# Altium schematic sheet Sch-QWA17_GPIO.SchDoc(Sch-QWA17_GPIO)
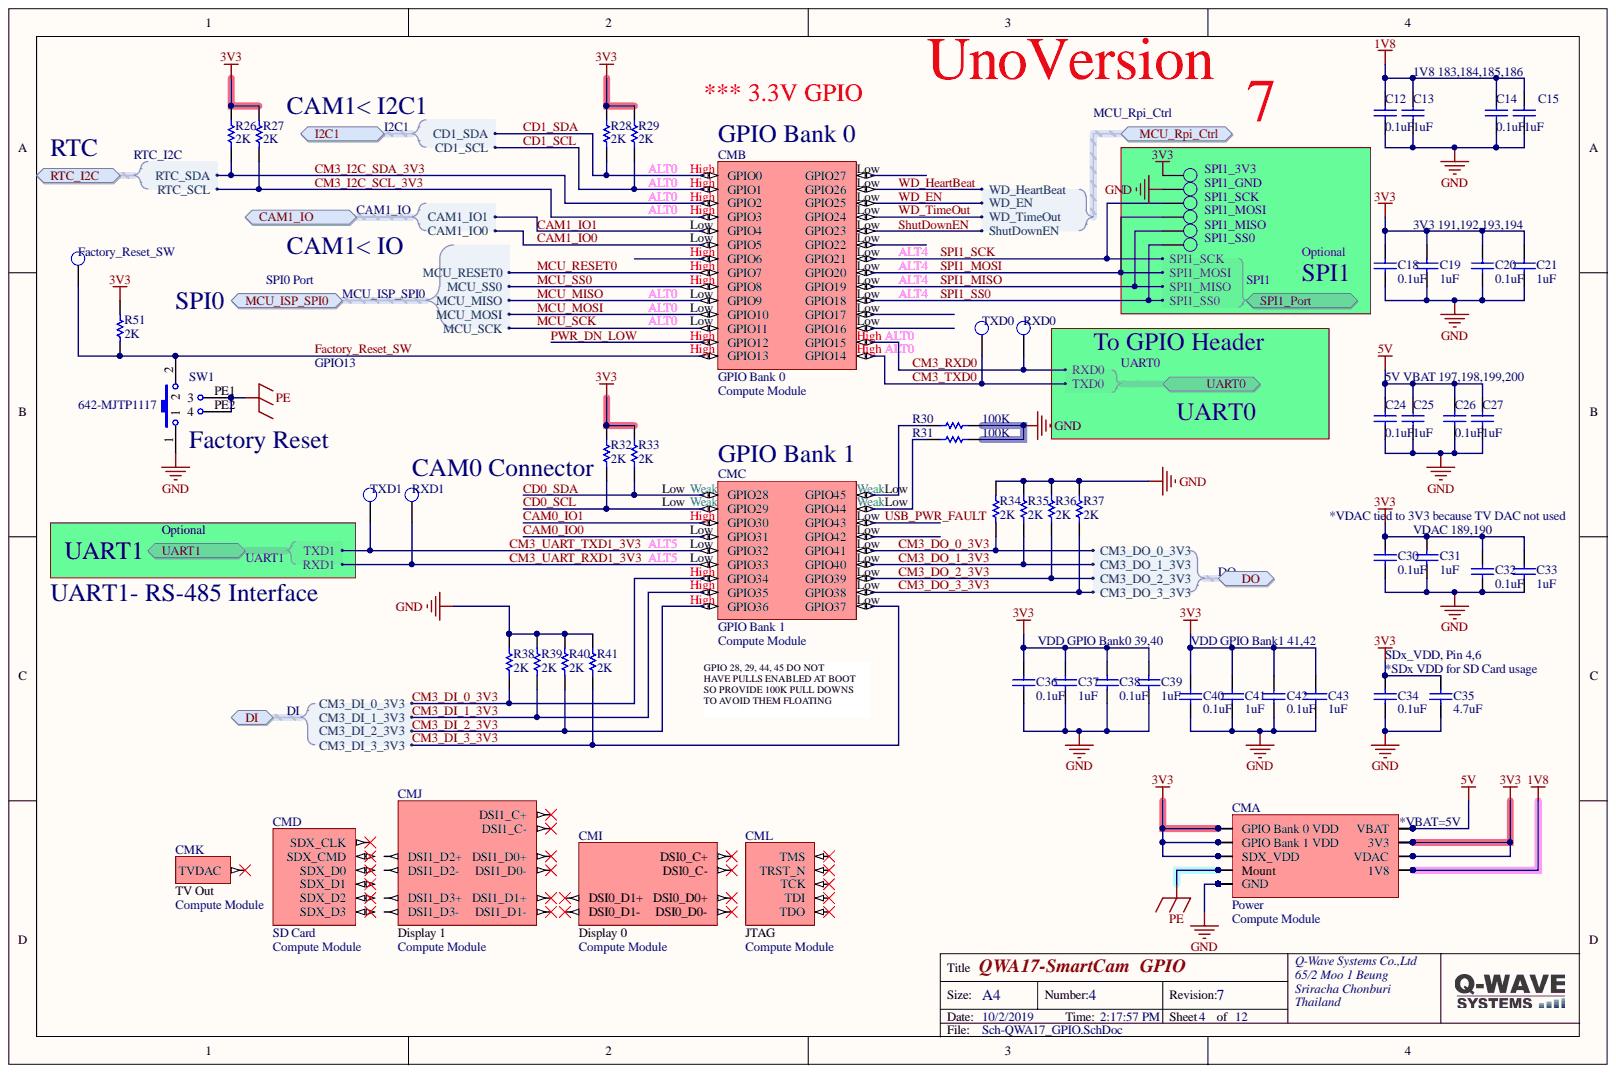
[diagram p0: Sch-QWA17_GPIO.SchDoc]

## Parts
C12  0.1uF  [CAP_0603]
C13  1uF  [CAP_0603]
C14  0.1uF  [CAP_0603]
C15  1uF  [CAP_0603]
C18  0.1uF  [CAP_0603]
C19  1uF  [CAP_0603]
C20  0.1uF  [CAP_0603]
C21  1uF  [CAP_0603]
C24  0.1uF  [CAP_0603]
C25  1uF  [CAP_0603]
C26  0.1uF  [CAP_0603]
C27  1uF  [CAP_0603]
C30  0.1uF  [CAP_0603]
C31  1uF  [CAP_0603]
C32  0.1uF  [CAP_0603]
C33  1uF  [CAP_0603]
C34  0.1uF  [CAP_0603]
C35  4.7uF  [6-0805_N]
C36  0.1uF  [CAP_0603]
C37  1uF  [CAP_0603]
C38  0.1uF  [CAP_0603]
C39  1uF  [CAP_0603]
C40  0.1uF  [CAP_0603]
C41  1uF  [CAP_0603]
C42  0.1uF  [CAP_0603]
C43  1uF  [CAP_0603]
CM  Compute Module  [1473005-4]  (parts A,B,C,D,I,J,K,L)
Factory_Reset_SW  Factory_Reset_SW  [TEST_POINT]
R26  2K  [RES_0603]
R27  2K  [RES_0603]
R28  2K  [RES_0603]
R29  2K  [RES_0603]
R30  100K  [RES_0603]
R31  100K  [RES_0603]
R32  2K  [RES_0603]
R33  2K  [RES_0603]
R34  2K  [RES_0603]
R35  2K  [RES_0603]
R36  2K  [RES_0603]
R37  2K  [RES_0603]
R38  2K  [RES_0603]
R39  2K  [RES_0603]
R40  2K  [RES_0603]
R41  2K  [RES_0603]
R51  2K  [RES_0603]
RXD0  RXD0  [TEST_POINT]
RXD1  RXD1  [TEST_POINT]
SPI1_3V3  SPI1_3V3  [TEST_POINT]
SPI1_GND  SPI1_GND  [TEST_POINT]
SPI1_MISO  SPI1_MISO  [TEST_POINT]
SPI1_MOSI  SPI1_MOSI  [TEST_POINT]
SPI1_SCK  SPI1_SCK  [TEST_POINT]
SPI1_SS0  SPI1_SS0  [TEST_POINT]
SW1  MJTP1117  Supplier Part Number 1: 642-MJTP1117  [TSW DIP-3*6*4.3_V]
TXD0  TXD0  [TEST_POINT]
TXD1  TXD1  [TEST_POINT]

## Ports
CAM1_IO, CAM1_IO.CAM1_IO0, CAM1_IO.CAM1_IO1, DI, DI.CM3_DI_0_3V3, DI.CM3_DI_1_3V3, DI.CM3_DI_2_3V3, DI.CM3_DI_3_3V3, DO, DO.CM3_DO_0_3V3, DO.CM3_DO_1_3V3, DO.CM3_DO_2_3V3, DO.CM3_DO_3_3V3, I2C1, I2C1.CD1_SCL, I2C1.CD1_SDA, MCU_ISP_SPI0, MCU_ISP_SPI0.MCU_MISO, MCU_ISP_SPI0.MCU_MOSI, MCU_ISP_SPI0.MCU_RESET0, MCU_ISP_SPI0.MCU_SCK, MCU_ISP_SPI0.MCU_SS0, MCU_RPI_CTRL, MCU_RPI_CTRL.SHUTDOWNEN, MCU_RPI_CTRL.WD_EN, MCU_RPI_CTRL.WD_HEARTBEAT, MCU_RPI_CTRL.WD_TIMEOUT, RTC_I2C, RTC_I2C.RTC_SCL, RTC_I2C.RTC_SDA, SPI1_PORT, SPI1_PORT.SPI1_MISO, SPI1_PORT.SPI1_MOSI, SPI1_PORT.SPI1_SCK, SPI1_PORT.SPI1_SS0, UART0, UART0.RXD0, UART0.TXD0, UART1, UART1.RXD1, UART1.TXD1

## Nets
1V8: C12.2 C13.2 C14.2 C15.2 CM.183(1V8) CM.184 CM.185 CM.186
3V3: C18.2 C19.2 C20.2 C21.2 C30.2 C31.2 C32.2 C33.2 C34.2 C35.2 C36.2 C37.2 C38.2 C39.2 C40.2 C41.2 C42.2 C43.2 CM.4(SDX_VDD) CM.6 CM.39 CM.40 CM.41 CM.42 CM.189(VDAC) CM.190 CM.191(3V3) CM.192 CM.193 CM.194 R26.2 R27.2 R28.2 R29.2 R32.2 R33.2 R51.2 SPI1_3V3.1
5V: C24.2 C25.2 C26.2 C27.2 CM.197(VBAT) CM.198 CM.199 CM.200
GND: C12.1 C13.1 C14.1 C15.1 C18.1 C19.1 C20.1 C21.1 C24.1 C25.1 C26.1 C27.1 C30.1 C31.1 C32.1 C33.1 C34.1 C35.1 C36.1 C37.1 C38.1 C39.1 C40.1 C41.1 C42.1 C43.1 CM.1 CM.7 CM.8 CM.13(GND) CM.14 CM.19 CM.20 CM.25 CM.26 CM.31 CM.32 CM.37 CM.38 CM.43 CM.44 CM.49 CM.50 CM.55 CM.56 CM.61 CM.62 CM.67 CM.68 CM.73 CM.74 CM.79 CM.80 CM.85 CM.86 CM.91 CM.92 CM.97 CM.98 CM.103 CM.104 CM.109 CM.110 CM.115 CM.116 CM.121 CM.122 CM.127 CM.133 CM.134 CM.139 CM.140 CM.145 CM.146 CM.151 CM.152 CM.157 CM.163 CM.164 CM.169 CM.170 CM.181 CM.182 CM.187 CM.188 CM.195 CM.196 R30.2 R31.2 R34.2 R35.2 R36.2 R37.2 R38.2 R39.2 R40.2 R41.2 SPI1_GND.1 SW1.1
PE: CM.203(Mount) CM.204 SW1.PE1 SW1.PE2
CAM0_IO0: CM.36(GPIO31)
CAM0_IO1: CM.34(GPIO30)
CAM1_IO0: CM.17(GPIO5) ; port CAM1_IO
CAM1_IO1: CM.15(GPIO4) ; port CAM1_IO
CD0_SCL: CM.30(GPIO29) R32.1
CD0_SDA: CM.28(GPIO28) R33.1
CD1_SCL: CM.5(GPIO1) R29.1 ; port I2C1
CD1_SDA: CM.3(GPIO0) R28.1 ; port I2C1
CM3_DI_0_3V3: CM.52(GPIO34) R38.1 ; port DI
CM3_DI_1_3V3: CM.54(GPIO35) R39.1 ; port DI
CM3_DI_2_3V3: CM.58(GPIO36) R40.1 ; port DI
CM3_DI_3_3V3: CM.60(GPIO37) R41.1 ; port DI
CM3_DO_0_3V3: CM.72(GPIO41) R34.1 ; port DO
CM3_DO_1_3V3: CM.70(GPIO40) R35.1 ; port DO
CM3_DO_2_3V3: CM.66(GPIO39) R36.1 ; port DO
CM3_DO_3_3V3: CM.64(GPIO38) R37.1 ; port DO
CM3_I2C_SCL_3V3: CM.11(GPIO3) R27.1 ; port RTC_I2C
CM3_I2C_SDA_3V3: CM.9(GPIO2) R26.1 ; port RTC_I2C
CM3_RXD0: CM.53(GPIO15) RXD0.1 ; port UART0
CM3_TXD0: CM.51(GPIO14) TXD0.1 ; port UART0
CM3_UART_RXD1_3V3: CM.48(GPIO33) RXD1.1 ; port UART1
CM3_UART_TXD1_3V3: CM.46(GPIO32) TXD1.1 ; port UART1
FACTORY_RESET_SW: CM.47(GPIO13) Factory_Reset_SW.1 R51.1 SW1.2
MCU_MISO: CM.29(GPIO9) ; port MCU_ISP_SPI0
MCU_MOSI: CM.33(GPIO10) ; port MCU_ISP_SPI0
MCU_RESET0: CM.23(GPIO7) ; port MCU_ISP_SPI0
MCU_SCK: CM.35(GPIO11) ; port MCU_ISP_SPI0
MCU_SS0: CM.27(GPIO8) ; port MCU_ISP_SPI0
PWR_DN_LOW: CM.45(GPIO12)
SHUTDOWNEN: CM.77(GPIO23) ; port MCU_RPI_CTRL
SPI1_MISO: CM.65(GPIO19) SPI1_MISO.1 ; port SPI1_PORT
SPI1_MOSI: CM.69(GPIO20) SPI1_MOSI.1 ; port SPI1_PORT
SPI1_SCK: CM.71(GPIO21) SPI1_SCK.1 ; port SPI1_PORT
SPI1_SS0: CM.63(GPIO18) SPI1_SS0.1 ; port SPI1_PORT
USB_PWR_FAULT: CM.78(GPIO43)
WD_EN: CM.83(GPIO25) ; port MCU_RPI_CTRL
WD_HEARTBEAT: CM.87(GPIO26) ; port MCU_RPI_CTRL
WD_TIMEOUT: CM.81(GPIO24) ; port MCU_RPI_CTRL
NetCM_82: CM.82(GPIO44) R31.1
NetCM_84: CM.84(GPIO45) R30.1

Not connected: CM 10(SDX_CLK) 12(SDX_CMD) 16(SDX_D0) 18(SDX_D1) 21(GPIO6) 22(SDX_D2) 24(SDX_D3) 57(GPIO16) 59(GPIO17) 75(GPIO22) 76(GPIO42) 89(GPIO27) 93(DSI0_D1-) 94(DSI1_D0+) 95(DSI0_D1+) 96(DSI1_D0-) 99(DSI0_D0-) 100(DSI1_C+) 101(DSI0_D0+) 102(DSI1_C-) 105(DSI0_C-) 106(DSI1_D3+) 107(DSI0_C+) 108(DSI1_D3-) 112(DSI1_D2+) 114(DSI1_D2-) 118(DSI1_D1+) 120(DSI1_D1-) 166(TVDAC) 172(TRST_N) 174(TDI) 176(TMS) 178(TDO) 180(TCK)

## Library data of the parts
C12 C14 C18 C20 C24 C26 C30 C32 C34 C36 C38 C40 C42 = Cap Semi: 0.1µF ±5% 50V Ceramic Capacitor X7R 0603; MFG: KEMET; MFG P/N: C0603C104J5RACTU; Component Kind: Standard; Library Name: Miscellaneous Devices.IntLib; Simulation: CAP
C13 C15 C19 C21 C25 C27 C31 C33 C37 C39 C41 C43 = Cap Semi: 1µF ±5% 50V Ceramic Capacitor X7R 0603; MFG: KEMET; MFG P/N: C0603C104J5RACTU; Component Kind: Standard; Library Name: Miscellaneous Devices.IntLib; Simulation: CAP
C35 = Cap: Capacitor 0805; Part Number: 0805F476M100NT; Component Kind: Standard; LatestRevisionDate: 17-Jul-2002; LatestRevisionNote: Re-released for DXP Platform.; Library Name: Miscellaneous Devices.IntLib; PackageDescription: Radial Cap, Thru-Hole; 2 Leads; 0.3 in Pin Spacing; PackageReference: RAD-0.3; Published: 8-Jun-2000; Simulation: CAP; Supplier: LCSC; Value: 4.7uF; Publisher: Altium Limited
CM = Compute Module: Raspberry Pi Compute Module; Component Kind: Standard; Library Name: Compute Module.SchLib
Factory_Reset_SW RXD0 RXD1 SPI1_3V3 SPI1_GND SPI1_MISO SPI1_MOSI SPI1_SCK SPI1_SS0 TXD0 TXD1 = TEST_POINT: Test Point; Component Kind: Standard; Library Name: Misc.SCHLIB
R26-R41 R51 = Res Semi: Resistor 0603; Component Kind: Standard; Library Name: Miscellaneous Devices.IntLib; Simulation: RES
SW1 = SW-PB: TACT SWITCH Size 6mmx4.3 MJTP1117; Component Kind: Standard; Supplier 1: Mouser; Library Name: QwaveLib.IntLib
